# Altium schematic sheet USB.SchDoc(USB)
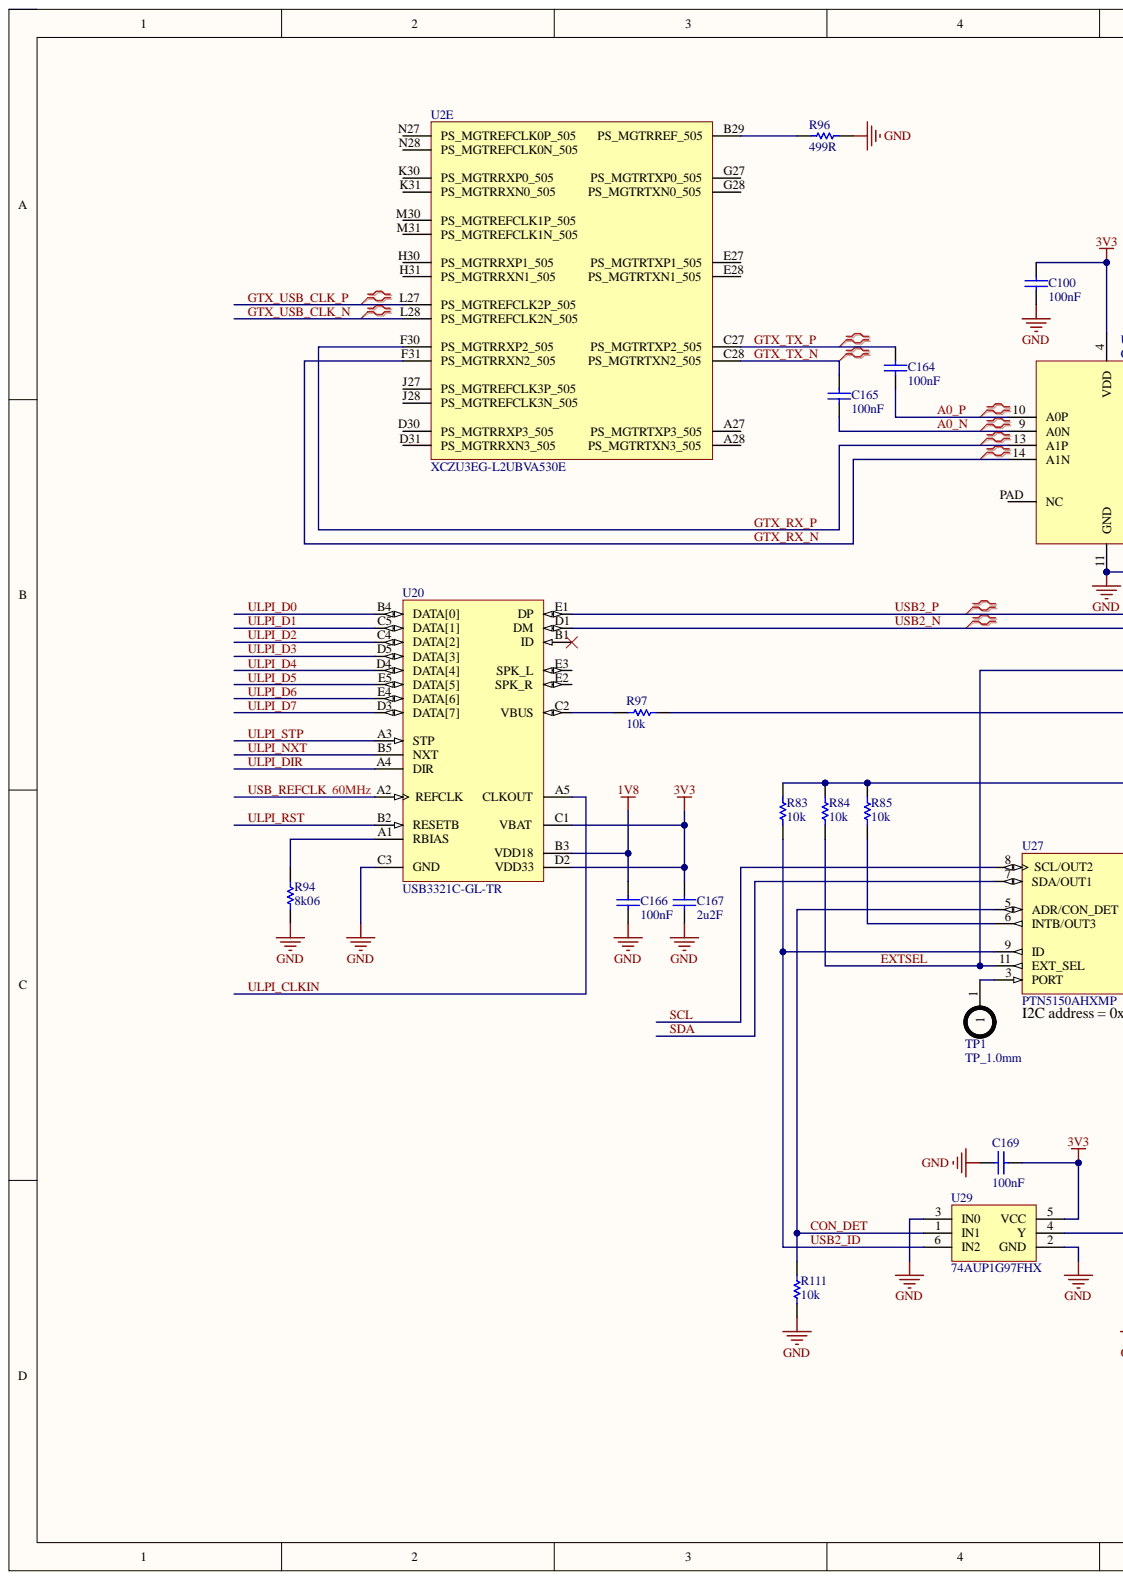
[diagram p0: USB.SchDoc, left]
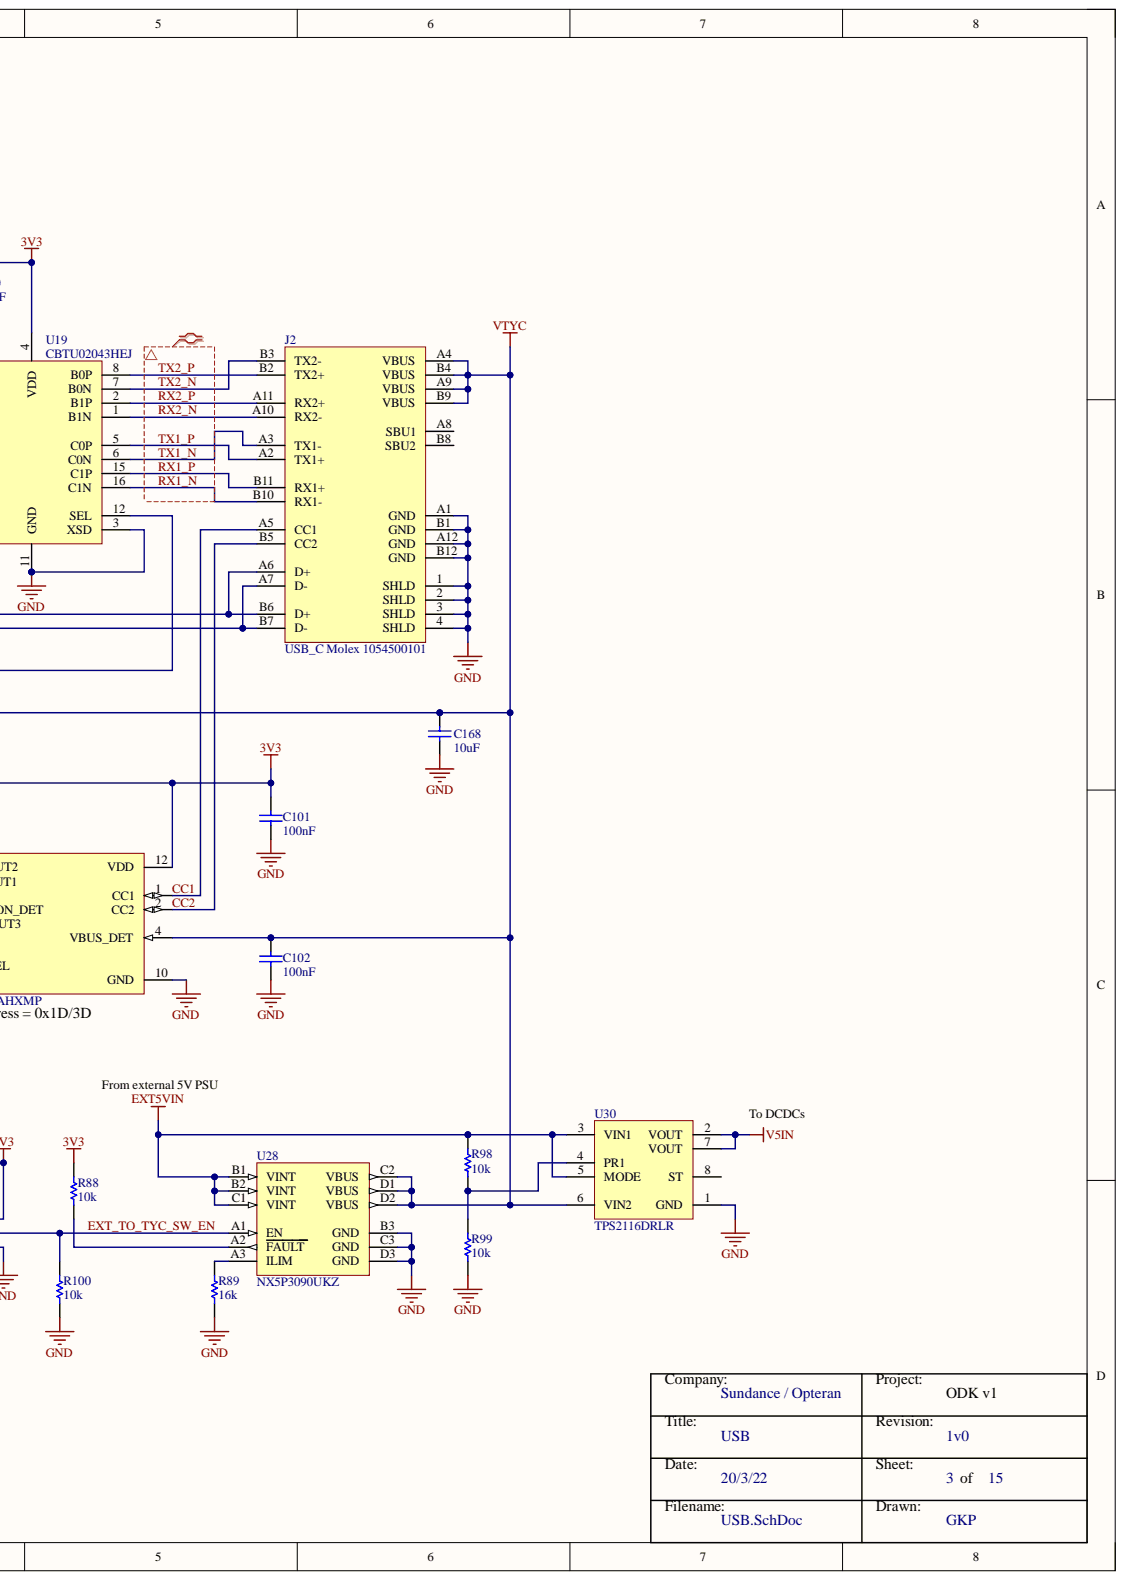
[diagram p1: USB.SchDoc, right]

## Parts
C100  100nF  [0201panERJ]
C101  100nF  [0201panERJ]
C102  100nF  [0201panERJ]
C164  100nF  [0201panERJ]
C165  100nF  [0201panERJ]
C166  100nF  [0201panERJ]
C167  2u2F  [0201panERJ]
C168  10uF  [CAP0402]
C169  100nF  [0201panERJ]
J2  USB_C Molex 1054500101  Manufacturer 1: Molex  Manufacturer Part Number 1: 1054500101  [FP-1054500101-MFG]
R83  10k  [RES0201]
R84  10k  [RES0201]
R85  10k  [RES0201]
R88  10k  [RES0201]
R89  16k  [RES0201]
R94  8k06  [RES0201]
R96  499R  [RES0201]
R97  10k  [RES0201]
R98  10k  [RES0201]
R99  10k  [RES0201]
R100  10k  [RES0201]
R111  10k  [RES0201]
TP1  TP_1.0mm  [TPR_1.0MM]  (no BOM)
U2  XCZU3EG-L2UBVA530E  [UBVA530]  (part E)
U19  CBTU02043HEJ  [HUQFN16]
U20  USB3321C-GL-TR  [WLCSP 25 0.4mm]
U27  PTN5150AHXMP  [USBCONTROLLER_PTN5150AHXMP]
U28  NX5P3090UKZ  [BGA12CP40_3X4_135X165X56B26N]
U29  74AUP1G97FHX  [MicroPak2 6-lead 1x1mm]
U30  TPS2116DRLR  [TI DRL0008A]

## Nets
1V8: C166.2 U20.B3(VDD18)
3V3: C100.2 C101.2 C167.2 C169.1 R83.1 R84.1 R85.1 R88.1 U19.4(VDD) U20.C1(VBAT) U20.D2(VDD33) U27.12(VDD) U29.5(VCC)
EXT5VIN: R98.1 U28.B1(VINT) U28.B2(VINT) U28.C1(VINT) U30.3(VIN1) U30.5(MODE)
GND: C100.1 C101.1 C102.1 C166.1 C167.1 C168.1 C169.2 J2.1(SHLD) J2.2(SHLD) J2.3(SHLD) J2.4(SHLD) J2.A1(GND) J2.A12(GND) J2.B1(GND) J2.B12(GND) R89.2 R94.2 R96.2 R99.2 R100.2 R111.2 U19.3(XSD) U19.11(GND) U20.C3(GND) U27.10(GND) U28.B3(GND) U28.C3(GND) U28.D3(GND) U29.2(GND) U29.3(IN0) U30.1(GND)
V5IN: U30.2(VOUT) U30.7(VOUT)
VTYC: C102.2 C168.2 J2.A4(VBUS) J2.A9(VBUS) J2.B4(VBUS) J2.B9(VBUS) R97.2 U27.4(VBUS_DET) U28.C2(VBUS) U28.D1(VBUS) U28.D2(VBUS) U30.6(VIN2)
A0_N: C165.2 U19.9(A0N)
A0_P: C164.2 U19.10(A0P)
CC1: J2.A5(CC1) U27.1(CC1)
CC2: J2.B5(CC2) U27.2(CC2)
CON_DET: R111.1 U27.5(ADR/CON_DET) U29.1(IN1)
EXT_TO_TYC_SW_EN: R100.1 U28.A1(EN) U29.4(Y)
EXTSEL: R84.2 U19.12(SEL) U27.11(EXT_SEL)
GTX_RX_N: U2.F31(PS_MGTRRXN2_505) U19.14(A1N)
GTX_RX_P: U2.F30(PS_MGTRRXP2_505) U19.13(A1P)
GTX_TX_N: C165.1 U2.C28(PS_MGTRTXN2_505)
GTX_TX_P: C164.1 U2.C27(PS_MGTRTXP2_505)
GTX_USB_CLK_N: U2.L28(PS_MGTREFCLK2N_505)
GTX_USB_CLK_P: U2.L27(PS_MGTREFCLK2P_505)
RX1_N: J2.B10(RX1-) U19.16(C1N)
RX1_P: J2.B11(RX1+) U19.15(C1P)
RX2_N: J2.A10(RX2-) U19.1(B1N)
RX2_P: J2.A11(RX2+) U19.2(B1P)
SCL: U27.8(SCL/OUT2)
SDA: U27.7(SDA/OUT1)
TX1_N: J2.A3(TX1-) U19.6(C0N)
TX1_P: J2.A2(TX1+) U19.5(C0P)
TX2_N: J2.B3(TX2-) U19.7(B0N)
TX2_P: J2.B2(TX2+) U19.8(B0P)
ULPI_CLKIN: U20.A5(CLKOUT)
ULPI_D0: U20.B4(DATA[0])
ULPI_D1: U20.C5(DATA[1])
ULPI_D2: U20.C4(DATA[2])
ULPI_D3: U20.D5(DATA[3])
ULPI_D4: U20.D4(DATA[4])
ULPI_D5: U20.E5(DATA[5])
ULPI_D6: U20.E4(DATA[6])
ULPI_D7: U20.D3(DATA[7])
ULPI_DIR: U20.A4(DIR)
ULPI_NXT: U20.B5(NXT)
ULPI_RST: U20.B2(RESETB)
ULPI_STP: U20.A3(STP)
USB2_ID: R83.2 U27.9(ID) U29.6(IN2)
USB2_N: J2.A7(D-) J2.B7(D-) U20.D1(DM)
USB2_P: J2.A6(D+) J2.B6(D+) U20.E1(DP)
USB_REFCLK: U20.A2(REFCLK)
NetR85_2: R85.2 U27.6(INTB/OUT3)
NetR88_2: R88.2 U28.A2(FAULT)
NetR89_1: R89.1 U28.A3(ILIM)
NetR94_1: R94.1 U20.A1(RBIAS)
NetR96_1: R96.1 U2.B29(PS_MGTRREF_505)
NetR97_1: R97.1 U20.C2(VBUS)
NetR98_2: R98.2 R99.1 U30.4(PR1)
NetTP1_1: TP1.1 U27.3(PORT)

Not connected: J2 A8(SBU1) B8(SBU2); U2 A27(PS_MGTRTXP3_505) A28(PS_MGTRTXN3_505) D30(PS_MGTRRXP3_505) D31(PS_MGTRRXN3_505) E27(PS_MGTRTXP1_505) E28(PS_MGTRTXN1_505) G27(PS_MGTRTXP0_505) G28(PS_MGTRTXN0_505) H30(PS_MGTRRXP1_505) H31(PS_MGTRRXN1_505) J27(PS_MGTREFCLK3P_505) J28(PS_MGTREFCLK3N_505) K30(PS_MGTRRXP0_505) K31(PS_MGTRRXN0_505) M30(PS_MGTREFCLK1P_505) M31(PS_MGTREFCLK1N_505) N27(PS_MGTREFCLK0P_505) N28(PS_MGTREFCLK0N_505); U19 PAD(NC); U20 B1(ID) E2(SPK_R) E3(SPK_L); U30 8(ST)

## Library data of the parts
C100-C102 C164-C166 C169 = Cap0201: 100nF 0201 16V X5R; Case-EIA: 0402; Component Kind: Standard; Library Name: Schematic Components.SchLib; Max Thickness: 0.6 mm; Technology: SMT
C167 = Cap0201: 2u2F 0201 6V3 X5R; Case-EIA: 0402; Component Kind: Standard; Library Name: Schematic Components.SchLib; Max Thickness: 0.6 mm; Technology: SMT
C168 = Cap0402: 10uF 0402 10V X5R; Case-EIA: 0402; Component Kind: Standard; Library Name: Schematic Components.SchLib; Max Thickness: 0.6 mm; Technology: SMT
J2 = USB_C Molex 1054500101: USB-C (USB TYPE-C) USB 3.2 Gen 2 (USB 3.1 Gen 2, Superspeed + (USB 3.1)) Receptacle Connector 24 Position Surface Mount, Right Angle; Through Hole; Component Kind: Standard; Library Name: Schematic Components.SchLib; Supplier 1: Digi-Key; Supplier Part Number 1: WM12856CT-ND
R83-R85 R88 R97-R100 R111 = Res0201: 10k 0201 1/16W 1%; Value: ?k; Component Kind: Standard; Library Name: Schematic Components.SchLib
R89 = Res0201: 16k 0201 1/16W 1%; Value: ?k; Component Kind: Standard; Library Name: Schematic Components.SchLib
R94 = Res0201: 8k06 0201 1/16W 1%; Value: ?k; Component Kind: Standard; Library Name: Schematic Components.SchLib
R96 = Res0201: 499R 0201 1/16W 1%; Value: ?k; Component Kind: Standard; Library Name: Schematic Components.SchLib
TP1 = TP_1.0mm: Test pad 1.0mm; Library Name: Connectors pads.SchLib
U2 = XCZU3EG-L2UBVA530E: SoC 32-bit/64-bit ARM Cortex-A53 RISC 1.5GHz Quad Core 530-Pin BGA; Manufacturer 1: Xilinx; Component Kind: Standard; Library Name: Xilinx1.SchLib; Manufacturer Part Number 1: XCZU3EG-L2UBVA530E; Supplier 1: Avnet; Supplier Part Number 1: XCZU3EG-L2UBVA530E
U19 = CBTU02043HEJ: USB Switch IC 2 Channel 16-HUQFN (1.6x2.4); Manufacturer 1: NXP USA Inc.; Component Kind: Standard; Library Name: Schematic Components.SchLib; Manufacturer Part Number 1: CBTU02043HEJ; Supplier 1: Digi-Key; Supplier Part Number 1: 568-13400-2-ND
U20 = USB3321C-GL-TR: USB Interface IC High Speed USB 1.8V ULPI; Manufacturer 1: Microchip Technology; Component Kind: Standard; Library Name: Schematic Components.SchLib; Manufacturer Part Number 1: USB3321C-GL-TR; Supplier 1: Mouser; Supplier Part Number 1: 886-USB3321C-GL-TR
U27 = PTN5150AHXMP: USB Controller - USB Interface 12-X2QFN (1.6x1.6); Manufacturer 1: NXP USA Inc.; Component Kind: Standard; Library Name: Schematic Components.SchLib; Manufacturer Part Number 1: PTN5150AHXMP; Supplier 1: Digi-Key; Supplier Part Number 1: 568-13083-2-ND
U28 = NX5P3090UKZ: Power Switch/Driver 1:1 - 3.3A 12-WLCSP (1.65x1.35); Manufacturer 1: NXP USA Inc.; Component Kind: Standard; Library Name: Schematic Components.SchLib; Manufacturer Part Number 1: NX5P3090UKZ; Supplier 1: Digi-Key; Supplier Part Number 1: 568-13049-2-ND
U29 = 74AUP1G97FHX: Logic Gates 2 Input, Low Power Config. Logic Gate; Manufacturer 1: onsemi / Fairchild; Component Kind: Standard; Library Name: Logic Interface.SchLib; Manufacturer Part Number 1: 74AUP1G97FHX; Supplier 1: Mouser; Supplier Part Number 1: 512-74AUP1G97FHX
U30 = TPS2116DRLR: Power Switch/Driver 1:1 N-Channel 2.5A SOT-583; Manufacturer 1: Texas Instruments; Component Kind: Standard; Library Name: Power Reg DCDC.SchLib; Manufacturer Part Number 1: TPS2116DRLR; Supplier 1: Digi-Key; Supplier Part Number 1: 296-TPS2116DRLRTR-ND
